# Altium schematic sheet 2_XTAL_JTAG_FLASH (2).SchDoc("2_XTAL_JTAG_FLASH (2)")
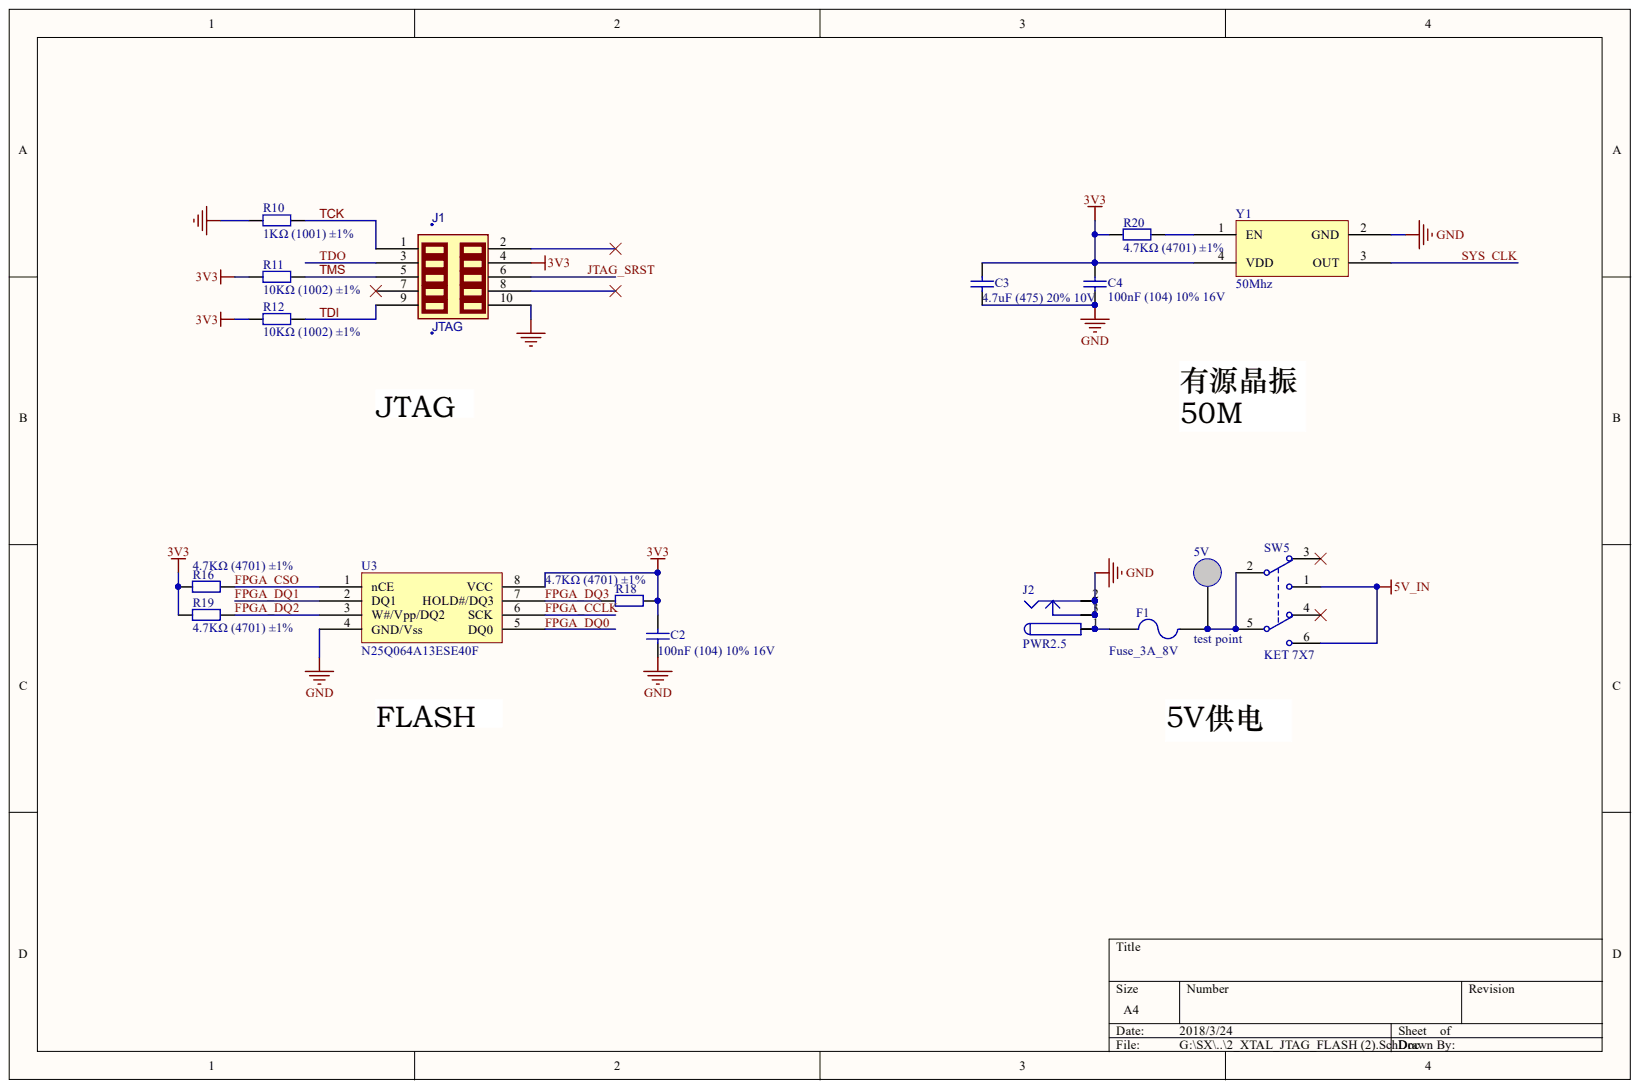
[diagram p0: 2_XTAL_JTAG_FLASH ]

## Parts
5V  test point  [TP_30mil]
C2  100nF (104) 10% 16V  [0402_C]
C3  4.7uF (475) 20% 10V  [0402_C]
C4  100nF (104) 10% 16V  [0402_C]
F1  Fuse_3A_8V  [1812]
J1  JTAG  [DC-2.54-L-Z-10P]
J2  PWR2.5  [DC-005]
R10  1KΩ (1001) ±1%  [0402_R]
R11  10KΩ (1002) ±1%  [0402_R]
R12  10KΩ (1002) ±1%  [0402_R]
R16  4.7KΩ (4701) ±1%  [0402_R]
R18  4.7KΩ (4701) ±1%  [0402_R]
R19  4.7KΩ (4701) ±1%  [0402_R]
R20  4.7KΩ (4701) ±1%  [0402_R]
SW5  KET 7X7  [KFT DIP-7X7]
U3  N25Q064A13ESE40F  [SO8W_208mil body]
Y1  50Mhz  [SMDXTAL3225]

## Nets
3V3: C2.2 C3.2 C4.2 J1.4 R11.1 R12.1 R16.1 R18.2 R19.1 R20.1 U3.8(VCC) Y1.4(VDD)
5V_IN: SW5.1 SW5.6
GND: C2.1 C3.1 C4.1 J1.10 J2.2 J2.2A J2.2B J2.3 J2.3A J2.3B R10.1 U3.4(GND/Vss) Y1.2(GND)
FPGA_CCLK: U3.6(SCK)
FPGA_CSO: R16.2 U3.1(nCE)
FPGA_DQ0: U3.5(DQ0)
FPGA_DQ1: U3.2(DQ1)
FPGA_DQ2: R19.2 U3.3(W#/Vpp/DQ2)
FPGA_DQ3: R18.1 U3.7(HOLD#/DQ3)
JTAG_SRST: J1.6
SYS_CLK: Y1.3(OUT)
TCK: J1.1 R10.2
TDI: J1.9 R12.2
TDO: J1.3
TMS: J1.5 R11.2
Net5V_1: 5V.1 F1.2 SW5.2 SW5.5
NetF1_1: F1.1 J2.1 J2.1A J2.1B
NetR20_2: R20.2 Y1.1(EN)

Not connected: J1 2 7 8; SW5 3 4

## Library data of the parts
5V = TP: Component Kind: Standard; Library Name: Personal_Accumulation_Sch.SchLib
C2 C4 = 0402 100nF (104) 10% 16V: 贴片电容; Component Kind: Standard; ComponentLink1Description: 供应商链接; ComponentLink1URL: http://www.szlcsc.com/product/details_1877.html; Library Name: Miscellaneous Devices LC.IntLib; Package: 0402; Supplier: LC; SuppliersPartNumber: C1525
C3 = 0402 4.7uF (475) 20% 10V: 贴片电容; Component Kind: Standard; ComponentLink1Description: 供应商链接; ComponentLink1URL: http://www.szlcsc.com/product/details_24469.html; Library Name: Miscellaneous Devices LC.IntLib; Package: 0402; Supplier: LC; SuppliersPartNumber: C23733
F1 = Fuse 2: Fuse保险丝; Component Kind: Standard; LatestRevisionDate: 17-Jul-2002; LatestRevisionNote: Re-released for DXP Platform.; Library Name: AX301_AX4010.IntLib; PackageDescription: Thru-Hole; 2 Leads; PackageReference: PIN-W2/E2.8; Published: 8-Jun-2000; Simulation: FUSE; Publisher: Altium Limited
J1 = JTAG-10_Dup1: Component Kind: Standard
J2 = PWR2.5: DC-005电源插座孔径5.5mm内圆针2.5mm; Component Kind: Standard; ComponentLink1Description: Manufacturer Link; ComponentLink1URL: http://domino.kycon.com/internet/Products.html; DatasheetDocument: 09-May-2002; Library Name: Miscellaneous Connectors.IntLib; Published: 17-Dec-2003; Publisher: Altium Limited
R10 = 0402 1KΩ (1001) 1%: 贴片电阻; Component Kind: Standard; ComponentLink1Description: 供应商链接; ComponentLink1URL: http://www.szlcsc.com/product/details_12256.html; Library Name: Miscellaneous Devices LC.IntLib; Package: 0402; Supplier: LC; SuppliersPartNumber: C11702
R11 R12 = 0402 10KΩ (1002) 1%: 贴片电阻; Component Kind: Standard; ComponentLink1Description: 供应商链接; ComponentLink1URL: http://www.szlcsc.com/product/details_26487.html; Library Name: Miscellaneous Devices LC.IntLib; Package: 0402; Supplier: LC; SuppliersPartNumber: C25744
R16 R18-R20 = 0402 4.7KΩ (4701) 1%: 贴片电阻; Component Kind: Standard; ComponentLink1Description: 供应商链接; ComponentLink1URL: http://www.szlcsc.com/product/details_26643.html; Library Name: Miscellaneous Devices LC.IntLib; Package: 0402; Supplier: LC; SuppliersPartNumber: C25900
SW5 = KET 7X7: 7*7自锁开关6脚; 试用产品: 如您满意请支持正品; BOM名称: 7*7自锁开关; Component Kind: Standard; 学习资源: www.ooocke.com; Library Name: 元件符号库.SchLib
U3 = N25Q064A13ESE40F: FLASH芯片; Component Kind: Standard; Library Name: N25Q064A13ESE40F.SchLib
Y1 = XTAL4: 有源晶振50M; Component Kind: Standard; Library Name: XTAL.SchLib
